# Altium schematic sheet MicrobitQwiic 138.SchDoc
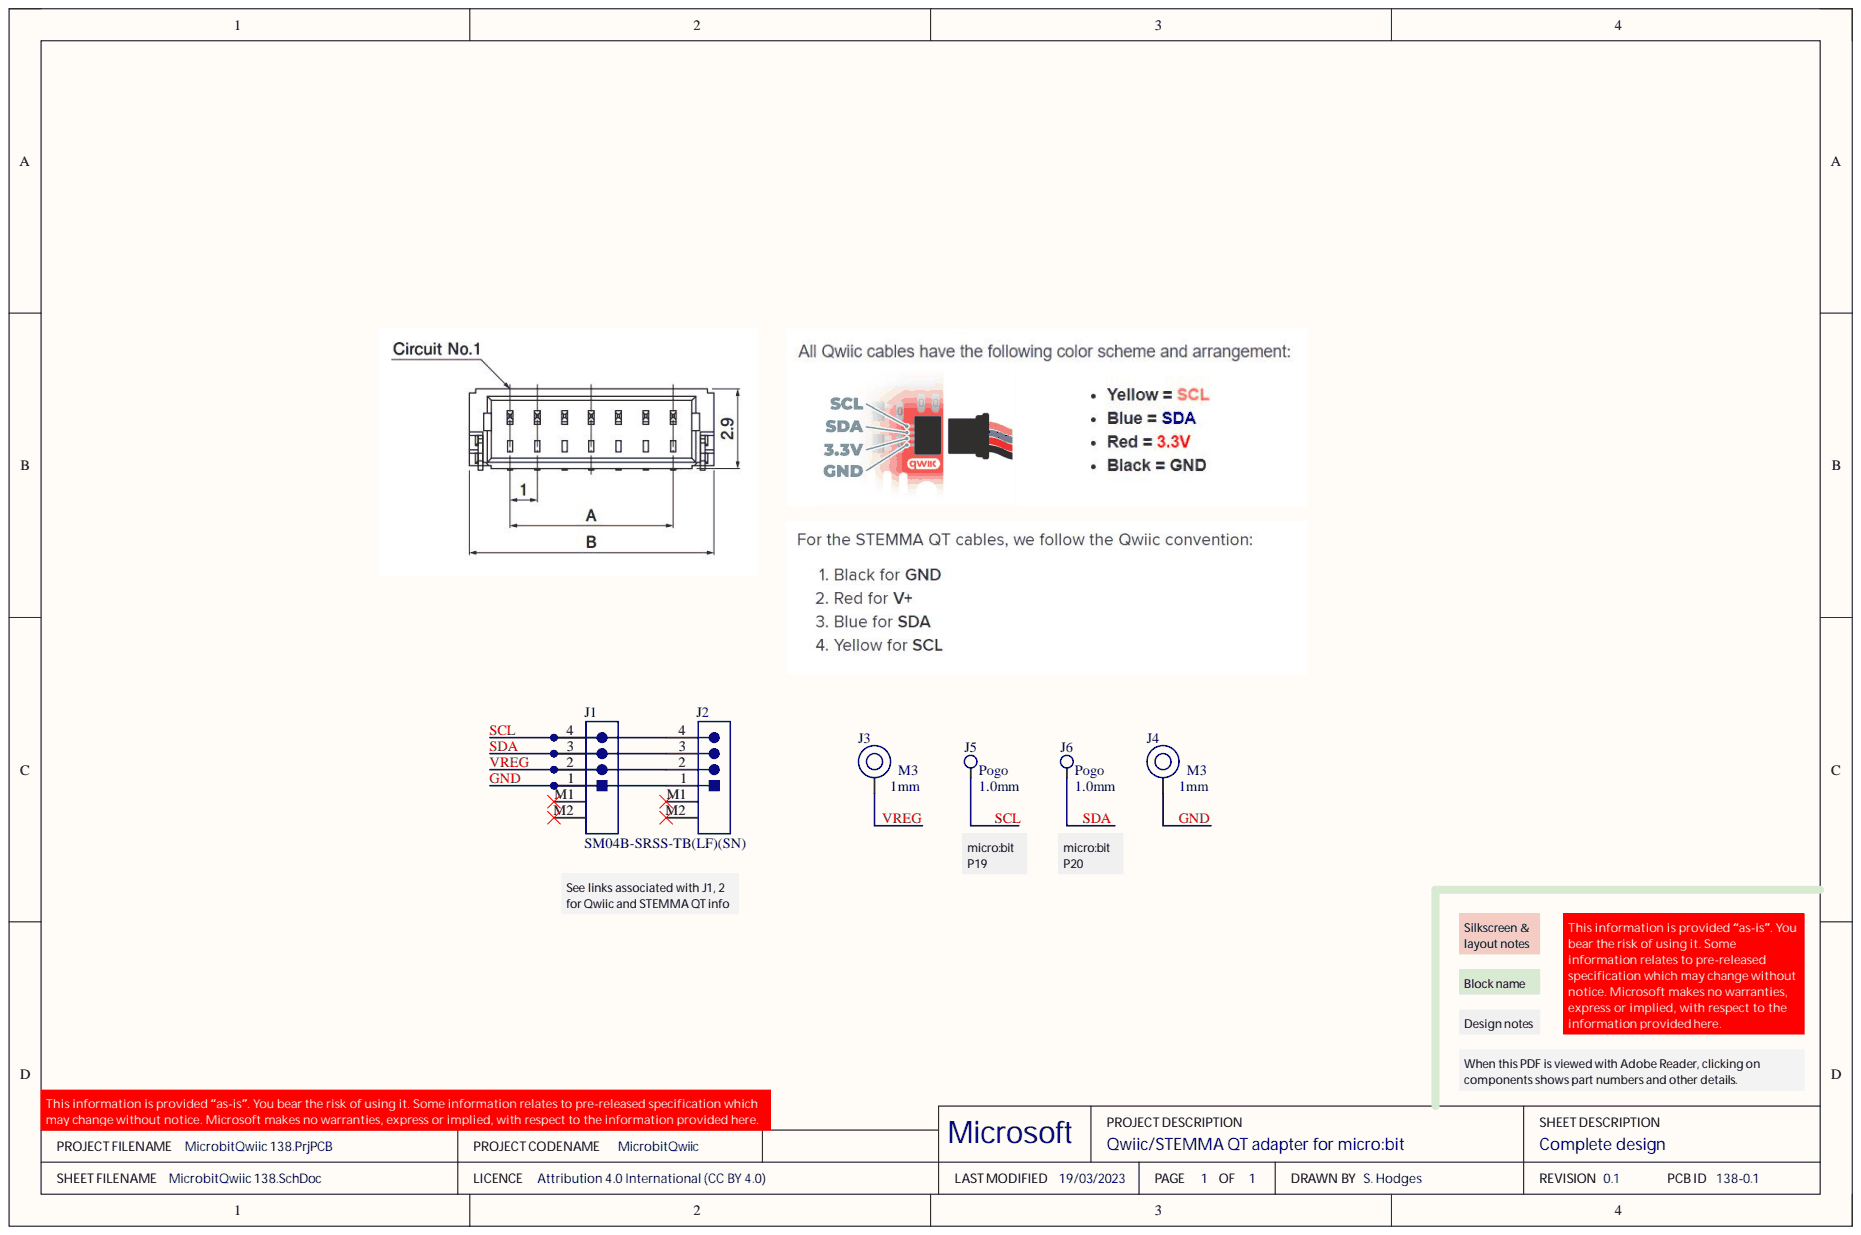
[diagram p0: MicrobitQwiic 138.SchDoc]

## Parts
J1  Manufacturer Part Number: SM04B-SRSS-TB(LF)(SN)
J2  Manufacturer Part Number: SM04B-SRSS-TB(LF)(SN)
J3  Diameter: M3  Standoff Height: 1mm
J4  Diameter: M3  Standoff Height: 1mm
J5  Working Height: 1.0mm
J6  Working Height: 1.0mm

## Nets
GND: J1.1 J2.1 J4.1
SCL: J1.4 J2.4 J5.1
SDA: J1.3 J2.3 J6.1
VREG: J1.2 J2.2 J3.1

Not connected: J1 M1 M2; J2 M1 M2

## Library data of the parts
J1 J2 = (no symbol name): CONN HEADER SMD R/A 4POS 1MM; Manufacturer: JST; Connector Type: Male Pin; Contact Resistance: 40mR; Max Current Rating: 1A; Max Voltage Rating (AC): 50V; Number of Contacts: 4; Pitch: 0.039inch; Category: Connectors; Color: Natural; ComponentLink1Description: Online datasheet; ComponentLink1URL: https://datasheet.ciiva.com/26894/getdatasheetpartid-499363-26894945.pdf?src-supplier=Verical; ComponentLink2Description: STEMMA QT info; ComponentLink2URL: https://learn.adafruit.com/introducing-adafruit-stemma-qt/what-is-stemma-qt; ComponentLink3Description: Qwiic info; ComponentLink3URL: https://www.sparkfun.com/qwiic; Contact Material: Copper Alloy; Contact Plating: Tin,Copper; Housing Color: Beige; Housing Material: Polyamide; Manufacturer 1: JST; Manufacturer Part Number 1: SM04B-SRSS-TB(LF)(SN); Max Operating Temperature: 85°C; Max Voltage Rating (DC): 50V; Min Operating Temperature: -25°C; Number of Positions: 4; Number of Rows: 1; Orientation: Right Angle; Supplier 1: Digi-Key; Supplier 2: Farnell; Supplier 3: Future Electronics; Supplier 4: Newark; Supplier Part Number 1: 455-1804-1-ND; Supplier Part Number 2: 1830839; Supplier Part Number 3: 4517173; Supplier Part Number 4: 87R0314; Termination: Solder
J5 J6 = (no symbol name): PCB 3A Spring contct SMT 1.4x1.4x1.2mm, working height 0.8-1.2mm; Manufacturer: Alps Electric; Manufacturer Part Number: SCTA2A0100; Category: Connectors; ComponentLink3Description: Alps webpage; ComponentLink3URL: https://tech.alpsalpine.com/e/products/detail/SCTA2A0100/; Manufacturer 1: Alps Electric; Manufacturer Part Number 1: SCTA2A0100; Supplier 1: Farnell; Supplier 2: Mouser; Supplier 3: LCSC; Supplier Part Number 1: 3261853; Supplier Part Number 2: 688-SCTA2A0100; Supplier Part Number 3: C351180
J3 J4 = (no symbol name): Supplier 1: LCSC; Supplier Part Number 1: C2916331
